# Altium schematic sheet SA_Sch.SchDoc
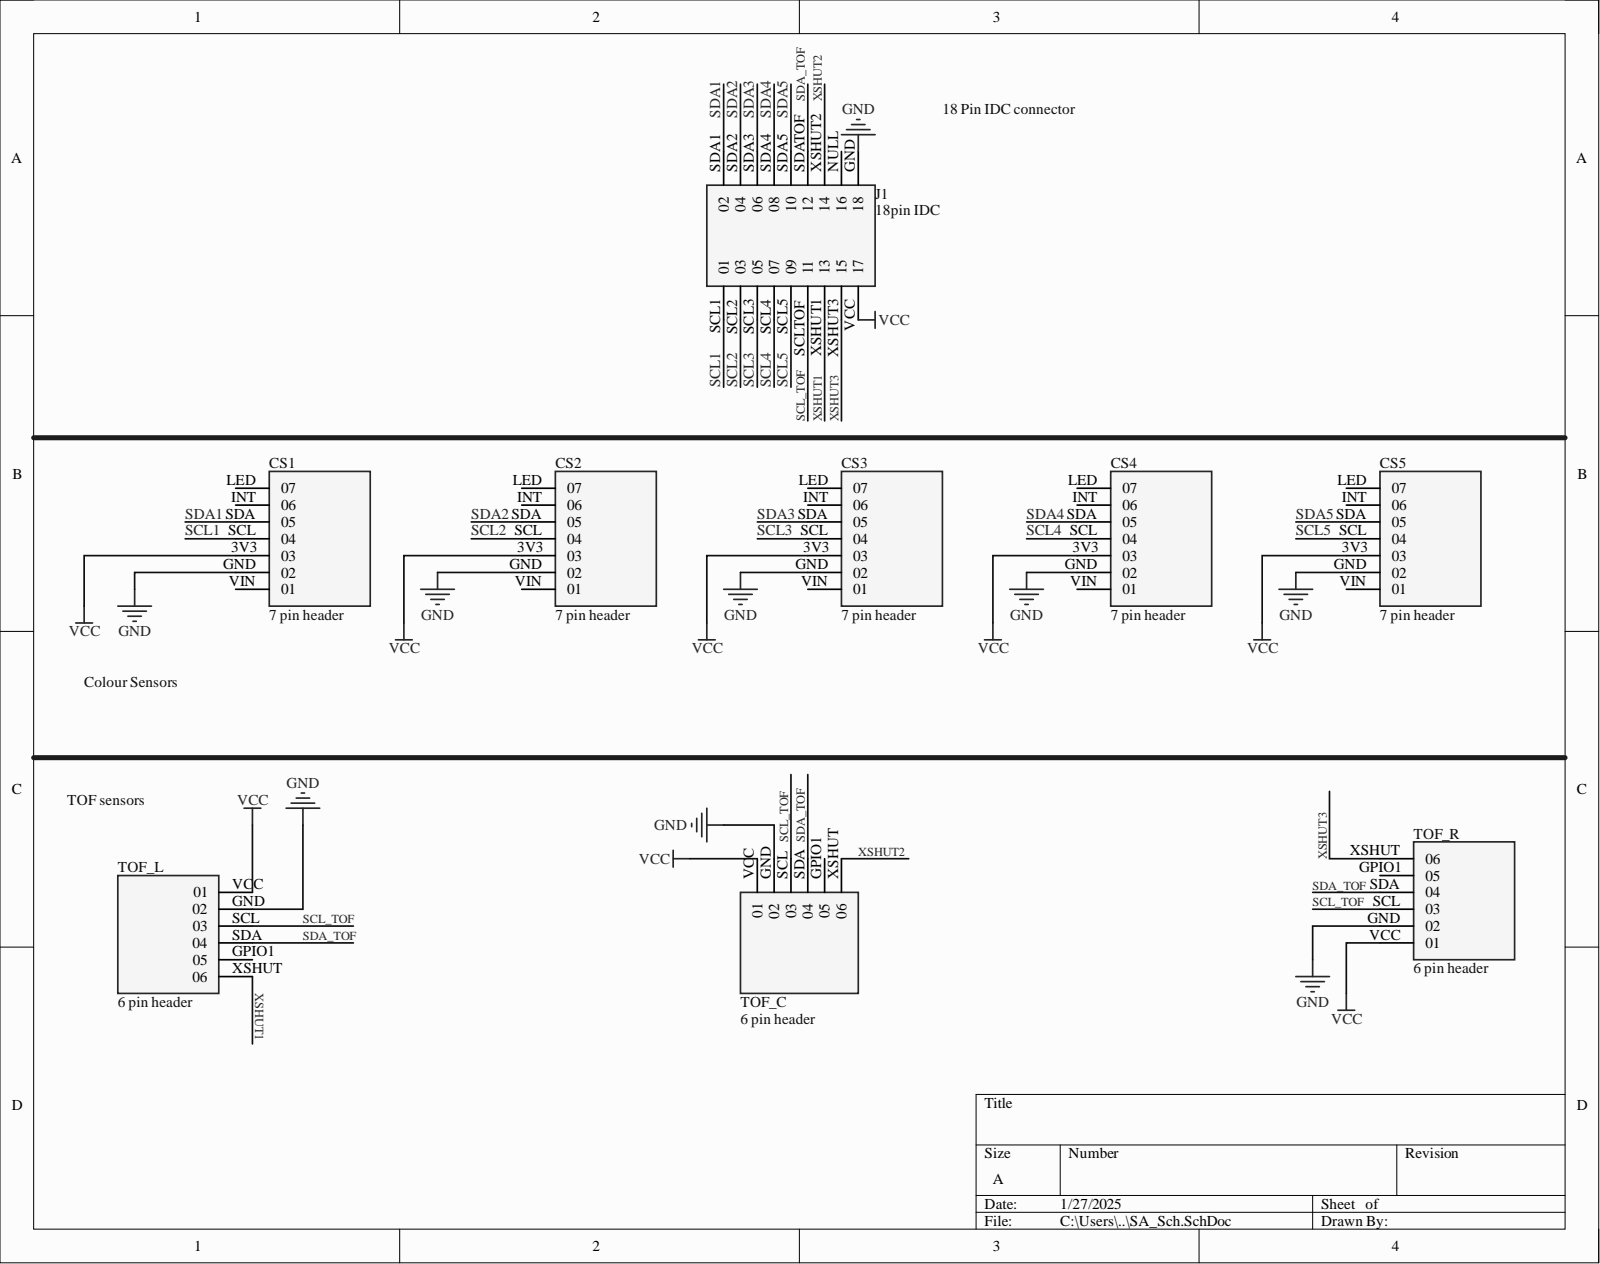
[diagram p0: SA_Sch.SchDoc]

## Parts
CS1  7 pin header  [7 pin header holes]
CS2  7 pin header  [7 pin header holes]
CS3  7 pin header  [7 pin header holes]
CS4  7 pin header  [7 pin header holes]
CS5  7 pin header  [7 pin header holes]
J1  18pin IDC  [IDC 18 pin]
TOF_C  6 pin header  [6 pin header]
TOF_L  6 pin header  [6 pin header]
TOF_R  6 pin header  [6 pin header]

## Nets
GND: CS1.GND CS2.GND CS3.GND CS4.GND CS5.GND J1.GND TOF_C.GND TOF_L.GND TOF_R.GND
VCC: CS1.3V3 CS2.3V3 CS3.3V3 CS4.3V3 CS5.3V3 J1.VCC TOF_C.VCC TOF_L.VCC TOF_R.VCC
SCL1: CS1.SCL J1.SCL1
SCL2: CS2.SCL J1.SCL2
SCL3: CS3.SCL J1.SCL3
SCL4: CS4.SCL J1.SCL4
SCL5: CS5.SCL J1.SCL5
SCL_TOF: J1.SCLTOF TOF_C.SCL TOF_L.SCL TOF_R.SCL
SDA1: CS1.SDA J1.SDA1
SDA2: CS2.SDA J1.SDA2
SDA3: CS3.SDA J1.SDA3
SDA4: CS4.SDA J1.SDA4
SDA5: CS5.SDA J1.SDA5
SDA_TOF: J1.SDATOF TOF_C.SDA TOF_L.SDA TOF_R.SDA
XSHUT1: J1.XSHUT1 TOF_L.XSHUT
XSHUT2: J1.XSHUT2 TOF_C.XSHUT
XSHUT3: J1.XSHUT3 TOF_R.XSHUT

Not connected: CS1 INT LED VIN; CS2 INT LED VIN; CS3 INT LED VIN; CS4 INT LED VIN; CS5 INT LED VIN; J1 NULL; TOF_C GPIO1; TOF_L GPIO1; TOF_R GPIO1

## Library data of the parts
CS1-CS5 = 7 pin header: 14 Position Header Connector Male Pin Gold; MF: Samtec; MP: TS-114-G-AA; Check_prices: https://www.snapeda.com/parts/TS-114-G-AA/Samtec/view-part/?ref=eda; Component Kind: Standard; Library Name: SA_SchLib.SchLib; Package: None; SnapEDA_Link: https://www.snapeda.com/parts/TS-114-G-AA/Samtec/view-part/?ref=snap
J1 = 18pin IDC: Slim Body Double-Row IDC Male Assemblies, 0.100 Pitch; MF: SAMTEC; MP: IDMD-10-S-07.00-G; Check_prices: https://www.snapeda.com/parts/IDMD-10-S-07.00-G/Samtec/view-part/?ref=eda; Component Kind: Standard; Library Name: SA_SchLib.SchLib; Package: None; SnapEDA_Link: https://www.snapeda.com/parts/IDMD-10-S-07.00-G/Samtec/view-part/?ref=snap
TOF_C TOF_L TOF_R = 6 pin header: 6 Position Header Connector Male Pin Gold; MF: Samtec; MP: TS-114-G-AA; Check_prices: https://www.snapeda.com/parts/TS-114-G-AA/Samtec/view-part/?ref=eda; Component Kind: Standard; Library Name: SA_SchLib.SchLib; Package: None; SnapEDA_Link: https://www.snapeda.com/parts/TS-114-G-AA/Samtec/view-part/?ref=snap
